# Altium schematic sheet relais_schaltung.SchDoc(relais_schaltung)
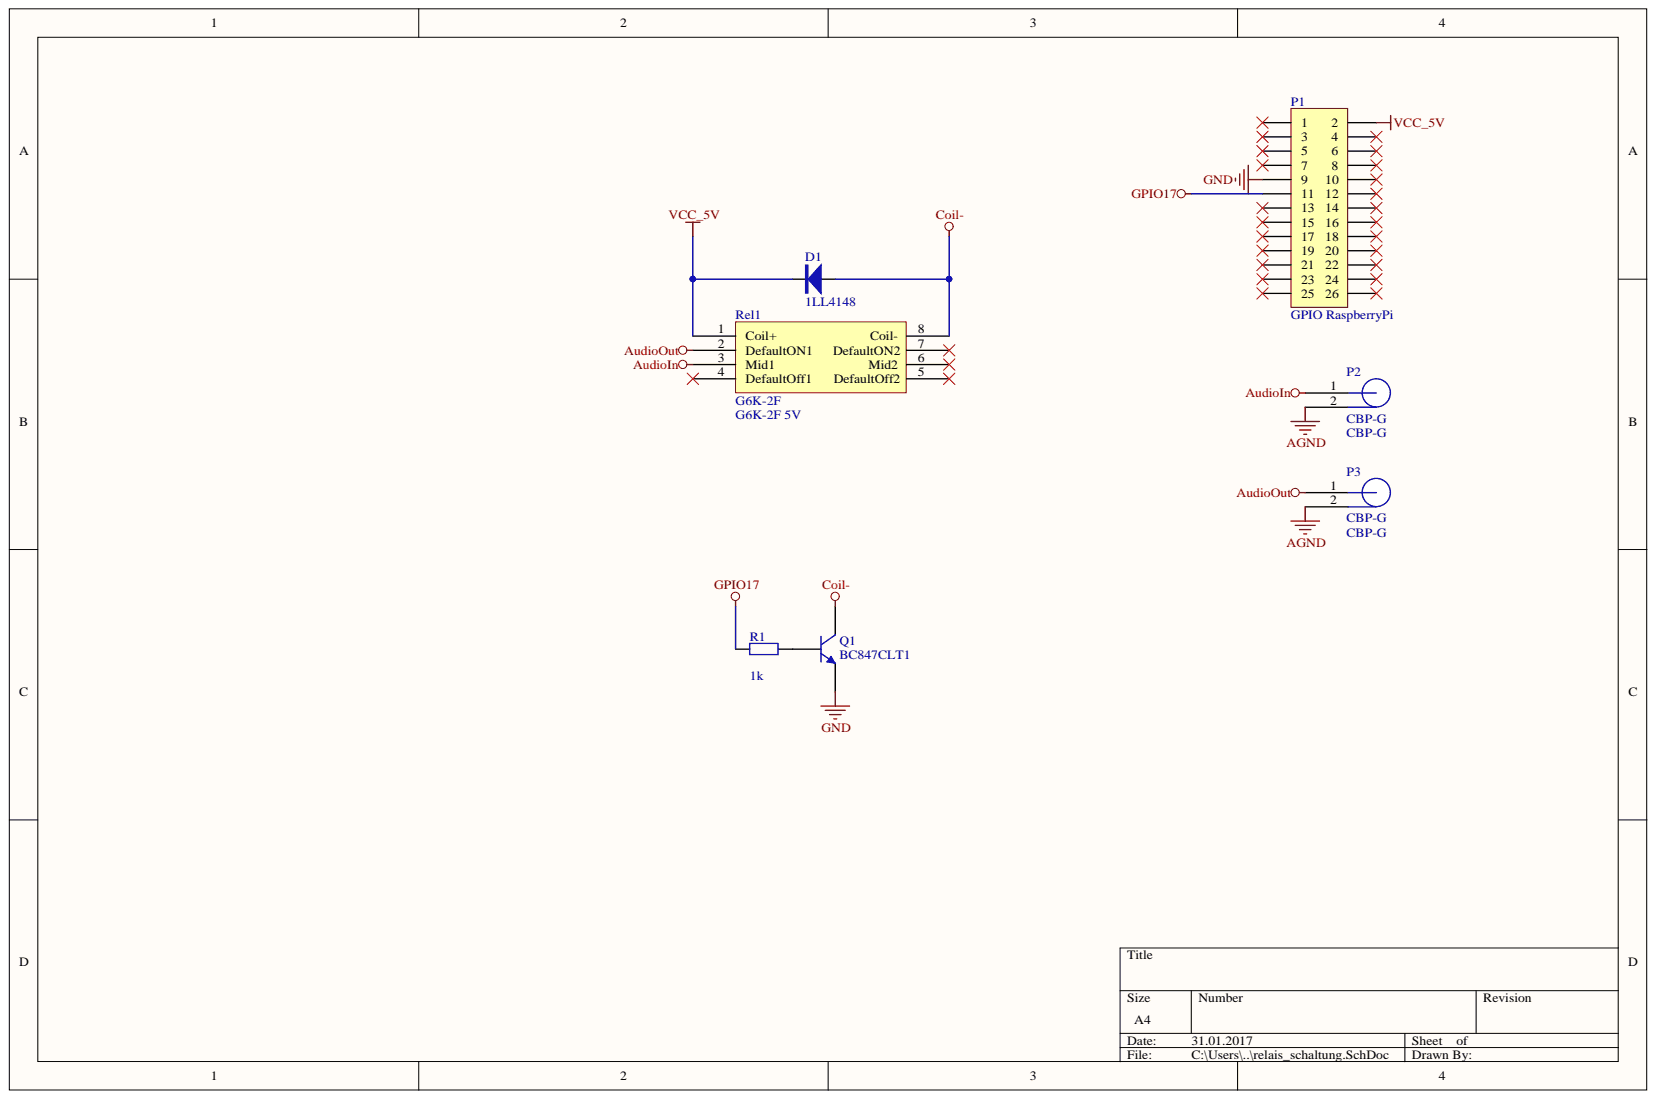
[diagram p0: relais_schaltung.SchDoc]

## Parts
D1  1LL4148  [1206]
P1  GPIO RaspberryPi  [HDR2X13]
P2  CBP-G  [Cinch]
P3  CBP-G  [Cinch]
Q1  BC847CLT1  [SOT-23]
R1  value 1k  [0805]
Rel1  G6K-2F  Reichelt: G6K-2F 5V  [G6K-2F]

## Nets
AGND: P2.2 P3.2
AUDIOIN: P2.1 Rel1.3(Mid1)
AUDIOOUT: P3.1 Rel1.2(DefaultON1)
COIL-: D1.1 Q1.3 Rel1.8(Coil-)
GND: P1.9 Q1.2
GPIO17: P1.11 R1.1
VCC_5V: D1.2 P1.2 Rel1.1(Coil+)
NetQ1_1: Q1.1 R1.2

Not connected: P1 1 3 4 5 6 7 8 10 12 13 14 15 16 17 18 19 20 21 22 23 24 25 26; Rel1 4(DefaultOff1) 5(DefaultOff2) 6(Mid2) 7(DefaultON2)

## Library data of the parts
D1 = 1N4148: Diode; Component Kind: Standard; Simulation: 1LL4148; Library Name: FKA_DXP_Source.SchLib
P1 = Header 13X2: Header, 13-Pin, Dual row; Component Kind: Standard; LatestRevisionDate: 17-Jul-2002; LatestRevisionNote: Re-released for DXP Platform.; Library Name: Miscellaneous Connectors.IntLib; Signal Integrity: Connector; Publisher: Altium Limited
P2 P3 = Cinch Print: Component Kind: Standard; Reichelt: CBP-G; Library Name: relais_schaltung.SchLib
Q1 = BC847CLT1: General Purpose Transistor NPN Silicon; PackageDocument: 1997; Component Kind: Standard; ComponentLink1Description: Manufacturer Link; ComponentLink1URL: http://www.onsemi.com/site/products/landing?taxonomyID=194; ComponentLink2Description: Datasheet; ComponentLink2URL: http://www.onsemi.com/pub/Collateral/BC846ALT1-D.PDF; DatasheetDocument: 1997; LatestRevisionDate: 17-Jul-2002; LatestRevisionNote: Re-released for DXP Platform.; Library Name: Motorola Discrete BJT.IntLib; PackageReference: 318-08; Published: 29-Sep-2000; Signal Integrity: BC847CLT1; Simulation: BC847CLT1; Publisher: Altium Limited
R1 = Res2: Resistor; Component Kind: Standard; LatestRevisionDate: 17-Jul-2002; LatestRevisionNote: Re-released for DXP Platform.; Library Name: Miscellaneous Devices.IntLib; PackageReference: AXIAL-0.4; Published: 8-Jun-2000; Signal Integrity: Res; Simulation: RESISTOR; Publisher: Altium Limited
Rel1 = G6K-2F: Component Kind: Standard; Library Name: relais_schaltung.SchLib
